# Altium schematic sheet 01-Christmas_Ornament.SchDoc(01-Christmas_Ornament)
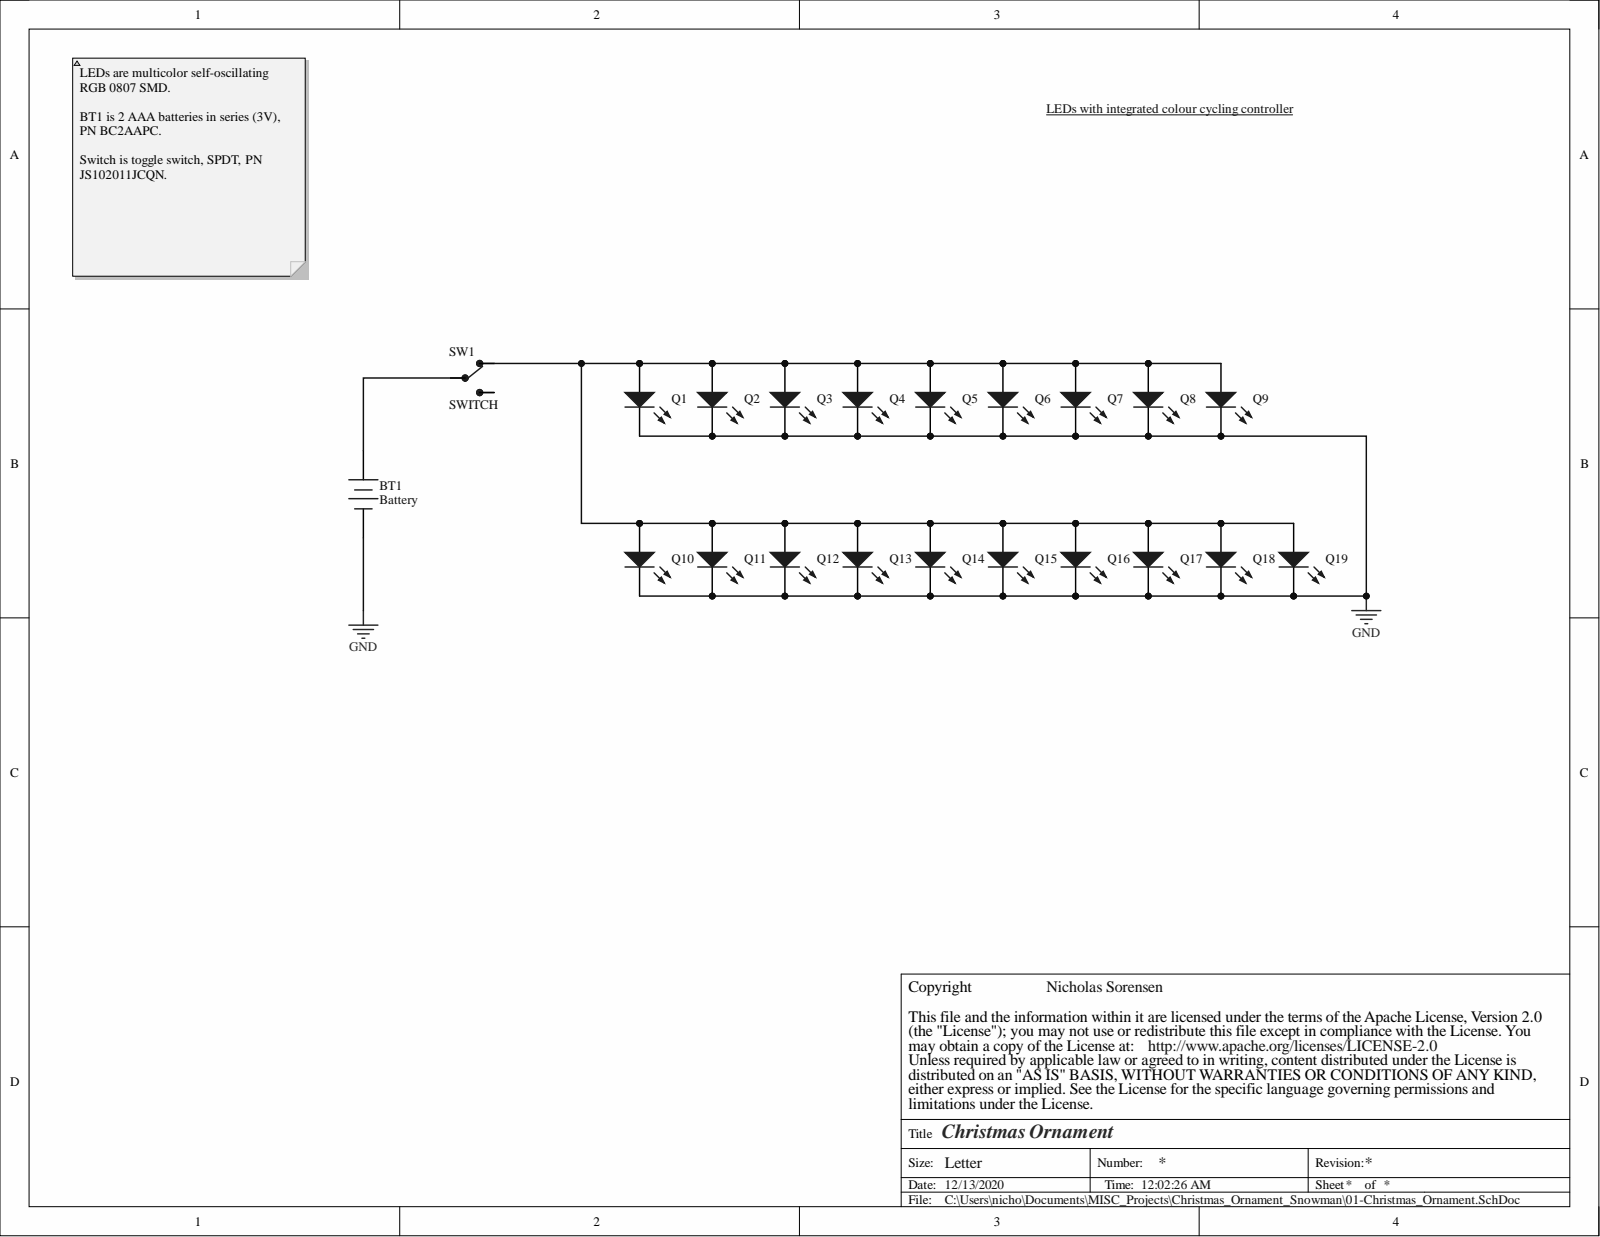
[diagram p0: 01-Christmas_Ornament.SchDoc]

## Parts
BT1  Battery  [AAA-2]
Q1  LED0805  [LED]  (value not drawn)
Q2  LED0805  [LED]  (value not drawn)
Q3  LED0805  [LED]  (value not drawn)
Q4  LED0805  [LED]  (value not drawn)
Q5  LED0805  [LED]  (value not drawn)
Q6  LED0805  [LED]  (value not drawn)
Q7  LED0805  [LED]  (value not drawn)
Q8  LED0805  [LED]  (value not drawn)
Q9  LED0805  [LED]  (value not drawn)
Q10  LED0805  [LED]  (value not drawn)
Q11  LED0805  [LED]  (value not drawn)
Q12  LED0805  [LED]  (value not drawn)
Q13  LED0805  [LED]  (value not drawn)
Q14  LED0805  [LED]  (value not drawn)
Q15  LED0805  [LED]  (value not drawn)
Q16  LED0805  [LED]  (value not drawn)
Q17  LED0805  [LED]  (value not drawn)
Q18  LED0805  [LED]  (value not drawn)
Q19  LED0805  [LED]  (value not drawn)
SW1  SWITCH  [SWITCH]

## Nets
GND: BT1.1 Q1.2 Q2.2 Q3.2 Q4.2 Q5.2 Q6.2 Q7.2 Q8.2 Q9.2 Q10.2 Q11.2 Q12.2 Q13.2 Q14.2 Q15.2 Q16.2 Q17.2 Q18.2 Q19.2
NetBT1_2: BT1.2 SW1.2
NetQ1_1: Q1.1 Q2.1 Q3.1 Q4.1 Q5.1 Q6.1 Q7.1 Q8.1 Q9.1 Q10.1 Q11.1 Q12.1 Q13.1 Q14.1 Q15.1 Q16.1 Q17.1 Q18.1 Q19.1 SW1.1

Not connected: SW1 3

## Library data of the parts
BT1 = Battery: Multicell Battery; Component Kind: Standard; LatestRevisionDate: 17-Jul-2002; LatestRevisionNote: Re-released for DXP Platform.; PackageDescription: Battery; 2 Leads; PackageReference: BAT-2; Published: 24-Mar-1999; Library Name: Christmas_Ornament_SCHlib.SchLib; Publisher: Altium Limited
Q1-Q19 = LED0805: Component Kind: Standard; Library Name: Christmas_Ornament_SCHlib.SchLib
SW1 = SWITCH: Component Kind: Standard; Library Name: Christmas_Ornament_SCHlib.SchLib
